# Altium schematic sheet RA_64pin_Local_Promotion_Board_1_RA2L1.SchDoc(RA_64pin_Local_Promotion_Board_1_RA2L1)
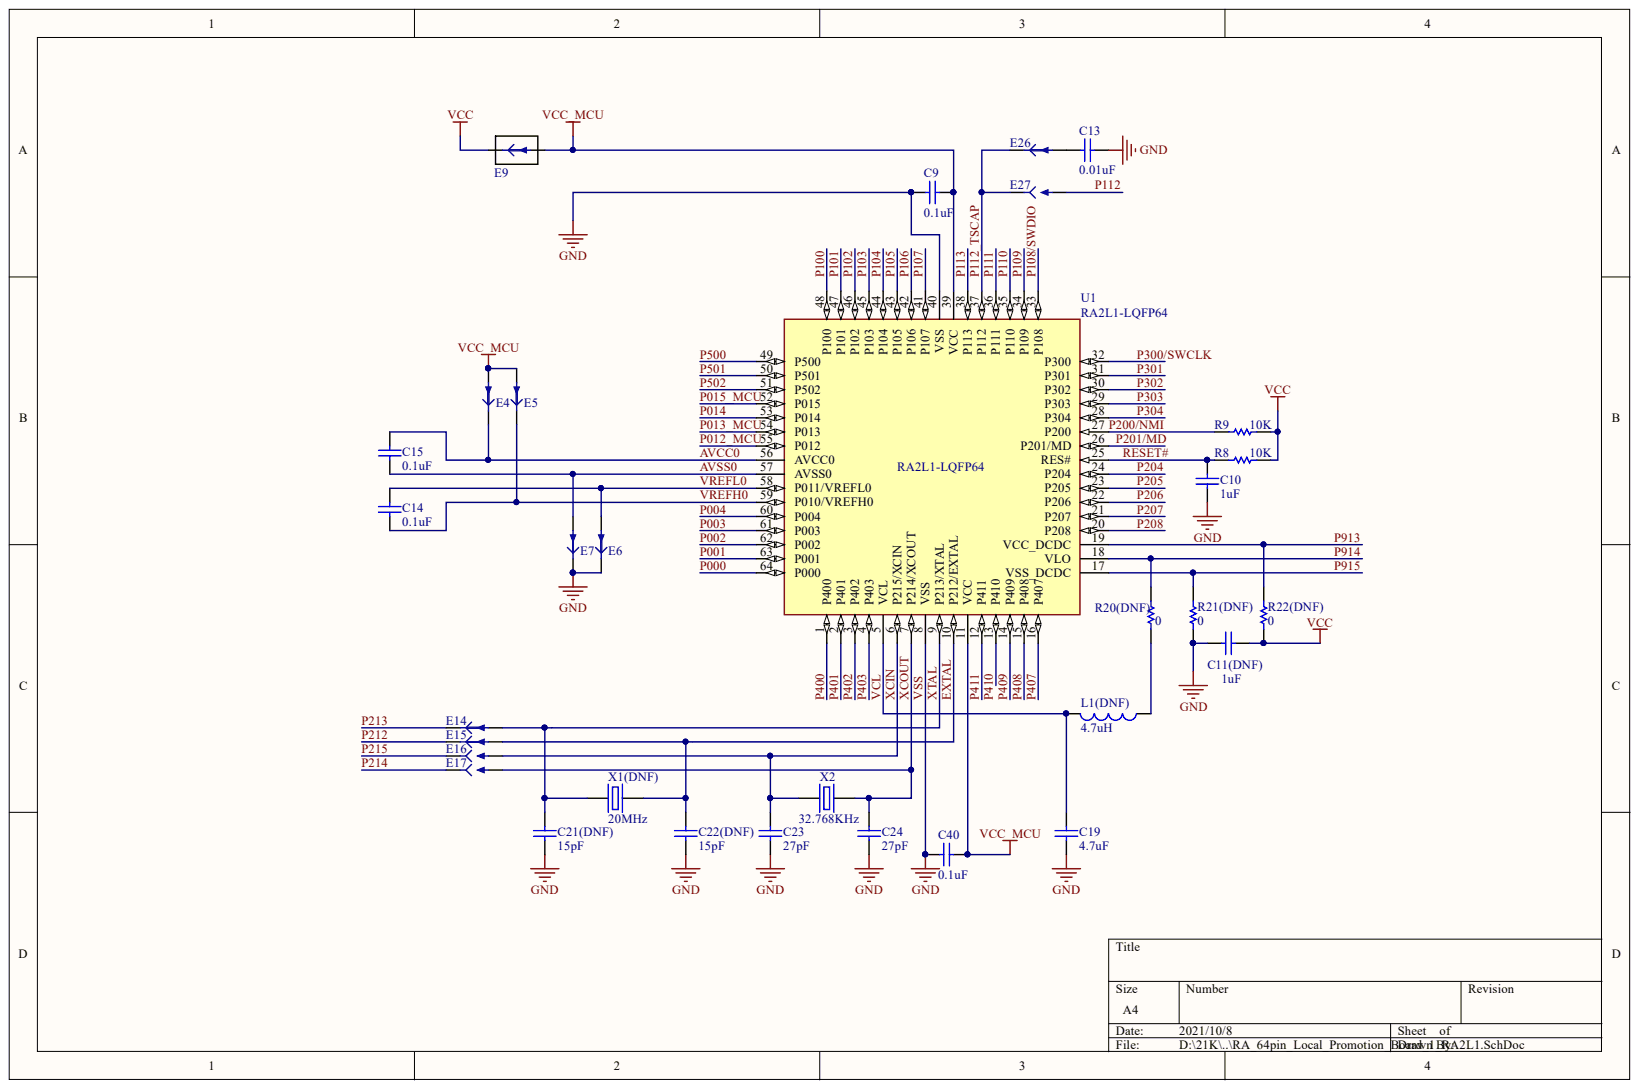
[diagram p0: RA_64pin_Local_Promotion_Board_1_RA2L1.SchDoc]

## Parts
C9  0.1uF  [CAPC0603-01]
C10  Capacitance: 1uF  [CAPC0603-01]  (hidden comment: 0.1uF)
C11(DNF)  Capacitance: 1uF  [CAPC0603-01]  (hidden comment: 0.1uF)
C13  0.1uF  Capacitance: 0.01uF  [CAPC0603-01]
C14  0.1uF  [CAPC0603-01]
C15  0.1uF  [CAPC0603-01]
C19  Capacitance: 4.7uF  [CAPC0603-01]  (hidden comment: 0.1uF)
C21(DNF)  15pF  [CAPC0603-01]
C22(DNF)  15pF  [CAPC0603-01]
C23  27pF  [CAPC0603-01]
C24  27pF  [CAPC0603-01]
C40  0.1uF  [CAPC0603-01]
E4  Jumper Trace Cut  [E_PadsTraceCut0805]  (value not drawn)  (no BOM)
E5  Jumper Trace Cut  [E_PadsTraceCut0805]  (value not drawn)  (no BOM)
E6  Jumper Trace Cut  [E_PadsTraceCut0805]  (value not drawn)  (no BOM)
E7  Jumper Trace Cut  [E_PadsTraceCut0805]  (value not drawn)  (no BOM)
E9  Dual Jumper Trace Cut  [CON-2A - B]  (value not drawn)  (no BOM)
E14  Jumper Trace Cut  [E_PadsTraceCut0805]  (value not drawn)  (no BOM)
E15  Jumper Trace Cut  [E_PadsTraceCut0805]  (value not drawn)  (no BOM)
E16  Jumper Solder Bridging  [E_PadsSplit0805]  (value not drawn)  (no BOM)
E17  Jumper Solder Bridging  [E_PadsSplit0805]  (value not drawn)  (no BOM)
E26  Jumper Trace Cut  [E_PadsTraceCut0805]  (value not drawn)  (no BOM)
E27  Jumper Solder Bridging  [E_PadsSplit0805]  (value not drawn)  (no BOM)
L1(DNF)  4.7uH  [BOURNS_SRN3015]
R8  10K  [RESC0603-01]
R9  10K  [RESC0603-01]
R20(DNF)  10K  [RESC0603-01]  (value not drawn)
R21(DNF)  10K  [RESC0603-01]  (value not drawn)
R22(DNF)  10K  [RESC0603-01]  (value not drawn)
U1  RA2L1-LQFP64  [RENESAS_PLQP0064KB-A_N]
X1(DNF)  20MHz  [OSC_5032]
X2  Frequency: 32.768KHz  [ABRACON_ABS07]  (hidden comment: 32.769KHz)

## Nets
VCC: C11(DNF).2 E9.1 R8.2 R9.2 R22(DNF).1
VCC_MCU: C9.2 C40.1 E4.2 E5.2 E9.2 U1.11(VCC) U1.39(VCC)
AVCC0: C15.1 E4.1 U1.56(AVCC0)
AVSS0: C15.2 E7.2 U1.57(AVSS0)
EXTAL: C22(DNF).2 E15.2 U1.10(P212/EXTAL) X1(DNF).2
P000: U1.64(P000)
P001: U1.63(P001)
P002: U1.62(P002)
P003: U1.61(P003)
P004: U1.60(P004)
P012_MCU: U1.55(P012)
P013_MCU: U1.54(P013)
P014: U1.53(P014)
P015_MCU: U1.52(P015)
P100: U1.48(P100)
P101: U1.47(P101)
P102: U1.46(P102)
P103: U1.45(P103)
P104: U1.44(P104)
P105: U1.43(P105)
P106: U1.42(P106)
P107: U1.41(P107)
P108/SWDIO: U1.33(P108)
P109: U1.34(P109)
P110: U1.35(P110)
P111: U1.36(P111)
P112: E27.2
P112_TSCAP: E26.1 E27.1 U1.37(P112)
P113: U1.38(P113)
P200/NMI: R9.1 U1.27(P200)
P201/MD: U1.26(P201/MD)
P204: U1.24(P204)
P205: U1.23(P205)
P206: U1.22(P206)
P207: U1.21(P207)
P208: U1.20(P208)
P212: E15.1
P213: E14.1
P214: E17.1
P215: E16.1
P300/SWCLK: U1.32(P300)
P301: U1.31(P301)
P302: U1.30(P302)
P303: U1.29(P303)
P304: U1.28(P304)
P400: U1.1(P400)
P401: U1.2(P401)
P402: U1.3(P402)
P403: U1.4(P403)
P407: U1.16(P407)
P408: U1.15(P408)
P409: U1.14(P409)
P411: U1.12(P411)
P500: U1.49(P500)
P501: U1.50(P501)
P502: U1.51(P502)
P913: R22(DNF).2 U1.19(VCC_DCDC)
P914: R20(DNF).2 U1.18(VLO)
P915: R21(DNF).2 U1.17(VSS_DCDC)
RESET#: C10.1 R8.1 U1.25(RES#)
VCL: C19.2 L1(DNF).2 U1.5(VCL)
VREFH0: C14.2 E5.1 U1.59(P010/VREFH0)
VREFL0: C14.1 E6.2 U1.58(P011/VREFL0)
VSS: C9.1 C10.2 C11(DNF).1 C13.2 C19.1 C21(DNF).1 C22(DNF).1 C23.1 C24.1 C40.2 E6.1 E7.1 R21(DNF).1 U1.8(VSS) U1.40(VSS)
XCIN: C23.2 E16.2 U1.6(P215/XCIN) X2.1
XCOUT: C24.2 E17.2 U1.7(P214/XCOUT) X2.2
XTAL: C21(DNF).2 E14.2 U1.9(P213/XTAL) X1(DNF).1
NetC13_1: C13.1 E26.2
NetL1(DNF)_1: L1(DNF).1 R20(DNF).1
P410:

Not connected: U1 13(P410)

## Library data of the parts
C9 C10 C11(DNF) C13-C15 C19 C40 = CAP-0603-0.1uF: Ceramic Chip Capacitor 0603 0.1uF 50V; Manufacturer: MURATA; Mfg Part Number: GCM188L81H104KA57D; Dialectric: X8L; Tolerance: 10%; Component Kind: Standard; Library Name: New Com.SchLib; Package: 0603; Voltage: 50V
  C9 C14 C15 C40: Capacitance: 0.1uF
C21(DNF) C22(DNF) = CAP-0603-15pF: Ceramic Chip Capacitor 0603 15pF 50V; Manufacturer: Murata; Mfg Part Number: GRM1885C1H120JA01D; Dialectric: COG; Tolerance: 5%; Capacitance: 15pF; Component Kind: Standard; Library Name: New Com.SchLib; Package: 0603; Voltage: 50V
C23 C24 = CAP-0603-27pF: Ceramic Chip Capacitor 0603 27pF 50V; Manufacturer: Murata; Mfg Part Number: GRM1885C1H120JA01D; Dialectric: COG; Tolerance: 5%; Capacitance: 27pF; Component Kind: Standard; Library Name: New Com.SchLib; Package: 0603; Voltage: 50V
E4-E7 E14 E15 E26 = E_JmpTraceCut: Pads on PCB with Trace Between for Cutting to isolate; Part Type: Jumper Trace Cut; Library Name: Synergy.SchLib
E9 = CON-2A - B: Pads on PCB with Trace Between for Cutting to isolate; Library Name: New Com.SchLib; Part Type: Jumper Trace Cut
E16 E17 E27 = E_JmpSldrBridge: Pads on PCB with Narrow Gap to allow Solder Bridging; Part Type: Jumper Solder Bridging; Library Name: Synergy.SchLib
L1(DNF) = IND-3015-4.7uH: Bourns Semishielded Power Inductor 4.7uH 1.2A; Mfg Part Number: SDR0302-4R7ML; Tolerance: 20%; Component Kind: Standard; Current: 1.2A; Inductance: 4.7uH; Manufacturer: Bourns; Package: SRN3015; Library Name: Synergy.IntLib
R8 R9 = RES-0603-10K: Chip Resistor Thick Film 0603 10K 1% 1/10W; Manufacturer: YAGEO; Mfg Part Number: RC0603FR-0710KL; Component Kind: Standard; Library Name: New Com.SchLib; Package: 0603; Power: 1/10W; Resistance: 10K; Tolerance: 1%
R20(DNF) R21(DNF) R22(DNF) = RES-0603-10K: Chip Resistor Thick Film 0603 10K 1% 1/10W; Manufacturer: YAGEO; Mfg Part Number: RC0603FR-0710KL; Resistance: 0; Component Kind: Standard; Library Name: New Com.SchLib; Package: 0603; Power: 1/10W; Tolerance: 1%
U1 = RA2L1-LQFP64: Renesas RA2L1 48MHz Cortex-M23 MCU LQFP64; Mfg Part Number: R7FA2L1AB2DFM#AA0; Architecture: ARM Cortex-M23; CAN: 1; Ethernet: 0; FLASH E2ROM: TBD; Component Kind: Standard; Display Name: RA2L1-LQFP64; FLASH ROM: TBD; Manufacturer: Renesas; Max Clock Speed: 48MHz; Package: LQFP-64; RAM: TBD; Library Name: Synergy.SchLib
X1(DNF) = XTL-32.769KHz SMT: Crystal 20MHz SMT; Manufacturer: Abracon; Mfg Part Number: ABS07-24MHz-T; Freq Stability: +/- 20ppm; Load Capacitance: 12.5pF; Component Kind: Standard; Frequency: 20MHz; Library Name: Synergy.SchLib
X2 = XTL-32.769KHz SMT: Crystal 32.769KHz 12.5pF SMT; Manufacturer: Abracon; Mfg Part Number: ABS07-32.768KHZ-T; Freq Stability: +/- 20ppm; Component Kind: Standard; Load Capacitance: 12.5pF; Library Name: Synergy.SchLib
